# Altium schematic sheet F4 DFC.SchDoc("F4 DFC")
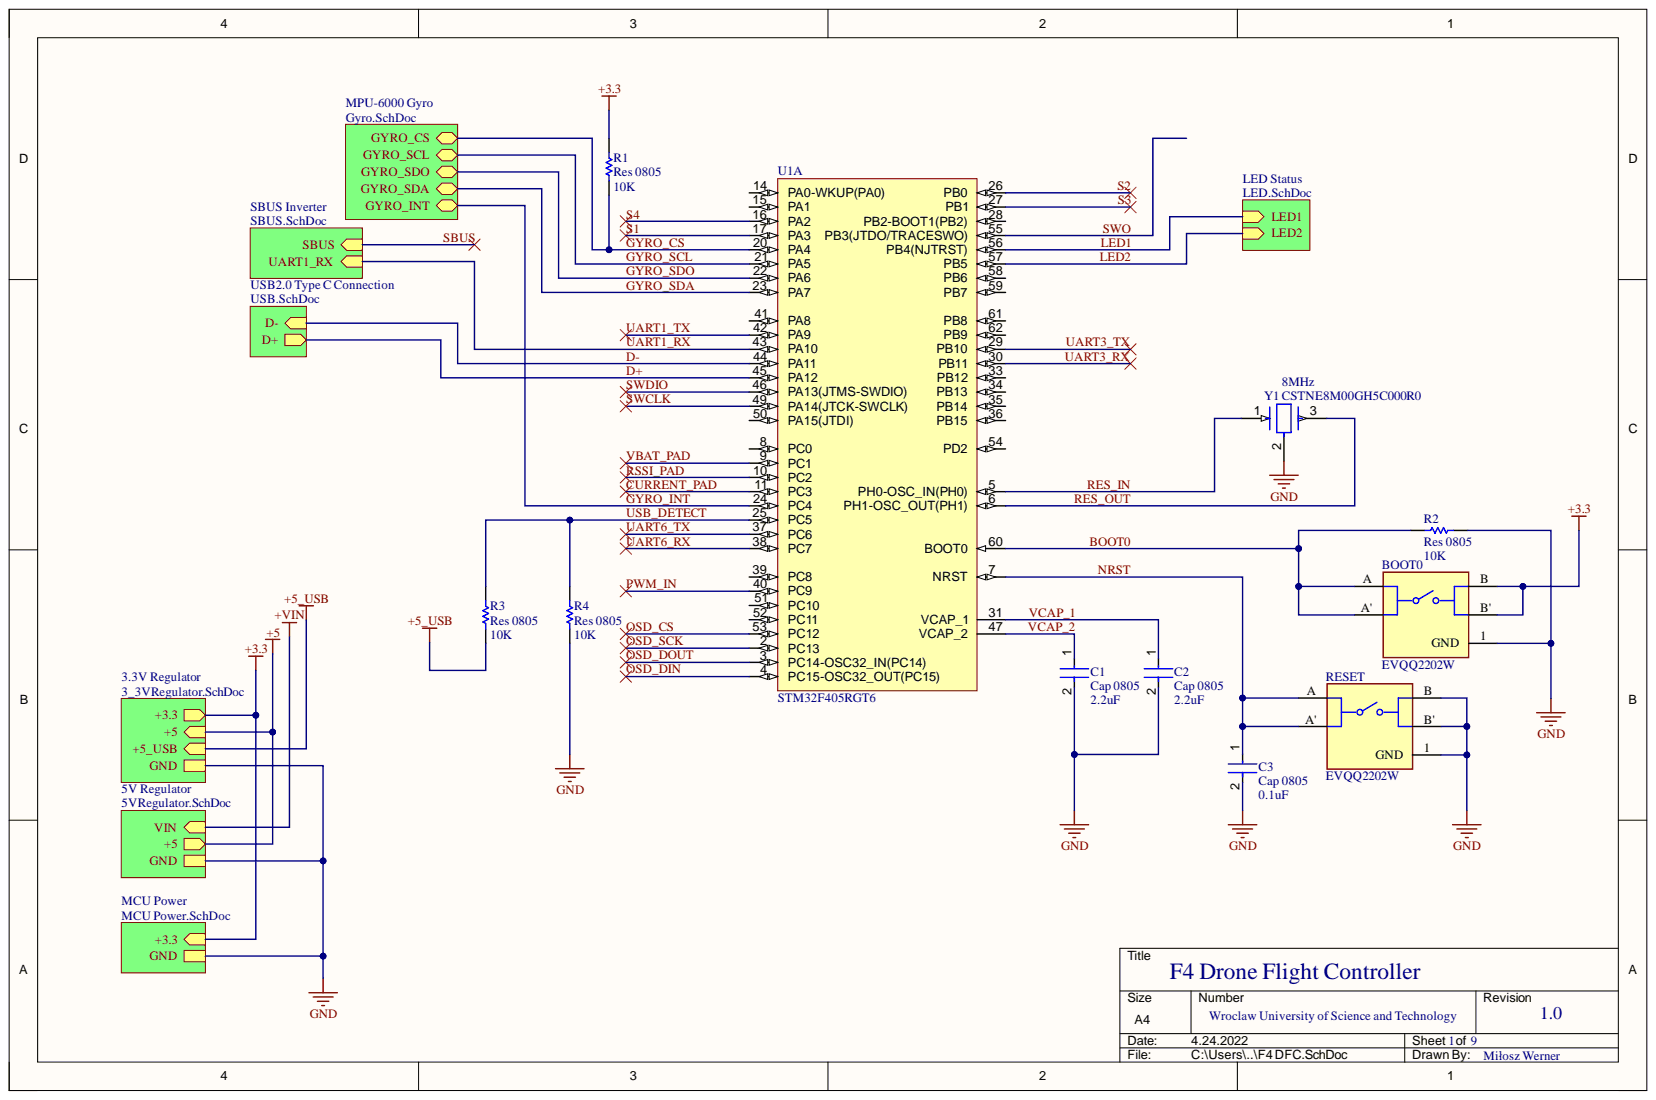
[diagram p0: F4 DFC.SchDoc]

## Parts
BOOT0  EVQQ2202W  [FP-EVQQ2202W-MFG]
C1  Cap 0805  value 2.2uF  [FP-GRM216-0_1-IPC_C]
C2  Cap 0805  value 2.2uF  Case Code (Imperial): 0805  [FP-GRM216-0_1-IPC_C]
C3  Cap 0805  value 0.1uF  [FP-GRM216-0_1-IPC_C]
R1  Res 0805  value 10K  [RESC2013X50X30LL20T20]
R2  Res 0805  value 10K  [RESC2013X50X30LL20T20]
R3  Res 0805  value 10K  [RESC2013X50X30LL20T20]
R4  Res 0805  value 10K  [RESC2013X50X30LL20T20]
RESET  EVQQ2202W  [FP-EVQQ2202W-MFG]
U1  STM32F405RGT6  [STM-LQFP64_V]  (part A)
Y1  CSTNE8M00GH5C000R0  value 8MHz  Frequency: 8MHz  [FP-CSTNE8M00GH5C000R0-MFG]

## Nets
+3.3: BOOT0.B BOOT0.B' R1.2
+5_USB: R3.1
GND: BOOT0.1(GND) C1.2 C2.2 C3.2 R2.1 R4.1 RESET.1(GND) RESET.B RESET.B' Y1.2
BOOT0: BOOT0.A BOOT0.A' R2.2 U1.60(BOOT0)
CURRENT_PAD: U1.11(PC3)
D+: U1.45(PA12)
D-: U1.44(PA11)
GYRO_CS: R1.1 U1.20(PA4)
GYRO_INT: U1.24(PC4)
GYRO_SCL: U1.21(PA5)
GYRO_SDA: U1.23(PA7)
GYRO_SDO: U1.22(PA6)
LED1: U1.56(PB4(NJTRST))
LED2: U1.57(PB5)
NRST: C3.1 RESET.A RESET.A' U1.7(NRST)
OSD_CS: U1.53(PC12)
OSD_DIN: U1.4(PC15-OSC32_OUT(PC15))
OSD_DOUT: U1.3(PC14-OSC32_IN(PC14))
OSD_SCK: U1.2(PC13)
PWM_IN: U1.40(PC9)
RES_IN: U1.5(PH0-OSC_IN(PH0)) Y1.1
RES_OUT: U1.6(PH1-OSC_OUT(PH1)) Y1.3
RSSI_PAD: U1.10(PC2)
S1: U1.17(PA3)
S2: U1.26(PB0)
S3: U1.27(PB1)
S4: U1.16(PA2)
SWCLK: U1.49(PA14(JTCK-SWCLK))
SWDIO: U1.46(PA13(JTMS-SWDIO))
SWO: U1.55(PB3(JTDO/TRACESWO))
UART1_RX: U1.43(PA10)
UART1_TX: U1.42(PA9)
UART3_RX: U1.30(PB11)
UART3_TX: U1.29(PB10)
UART6_RX: U1.38(PC7)
UART6_TX: U1.37(PC6)
USB_DETECT: R3.2 R4.2 U1.25(PC5)
VBAT_PAD: U1.9(PC1)
VCAP_1: C2.1 U1.31(VCAP_1)
VCAP_2: C1.1 U1.47(VCAP_2)
NetU1_8: U1.8(PC0)
NetU1_14: U1.14(PA0-WKUP(PA0))
NetU1_15: U1.15(PA1)
NetU1_28: U1.28(PB2-BOOT1(PB2))
NetU1_33: U1.33(PB12)
NetU1_34: U1.34(PB13)
NetU1_35: U1.35(PB14)
NetU1_36: U1.36(PB15)
NetU1_39: U1.39(PC8)
NetU1_41: U1.41(PA8)
NetU1_50: U1.50(PA15(JTDI))
NetU1_51: U1.51(PC10)
NetU1_52: U1.52(PC11)
NetU1_54: U1.54(PD2)
NetU1_58: U1.58(PB6)
NetU1_59: U1.59(PB7)
NetU1_61: U1.61(PB8)
NetU1_62: U1.62(PB9)
+5:
+VIN:
SBUS:

## Library data of the parts
BOOT0 RESET = CMP-05618-000131-1: SWITCH TACTILE SPST-NO 0.02A 15V; Actuator Color: White; Actuator Length: 0.7mm; Actuator Type: Standard; Body Depth: 6mm; Contact Current Rating: 20mA; Contact Resistance: 100mR; Electrical Life: 100000Cycles; Illumination Type: Non-Illuminated; Max Voltage Rating (AC): 250V; Operating Force: 3.5N; Switch Function: Off-Mom; Switch Travel: 0.25mm; Throw Configuration: SPST; Case/Package: SMD/SMT; Color: White; Component Kind: Standard; Contact Plating: Silver; Current Rating: 20mA; Depth: 6.6mm; Lead Free: Lead Free; Length: 6mm; Library Name: Altium Content Vault; Max Current Rating: 20mA; Max Operating Temperature: 85°C; Max Voltage Rating (DC): 15V; Mechanical Life: 100000Cycles; Min Operating Temperature: -40°C; Mount: Surface Mount; Orientation: Straight; Package Quantity: 1; Packaging: Tape and Reel; Radiation Hardening: No; REACH SVHC: No SVHC; RoHS Compliant: Yes; Series: EVQQ2; Size: 1.8mm; Termination: SMD/SMT; Thickness: 1.8mm; Voltage Rating (AC): 250V; Voltage Rating (DC): 15V
C1-C3 = CMP-2007-00889-2: Capacitor; Capacitance: 100nF; Case/Package: 0805; Component Kind: Standard; Library Name: Altium Content Vault; Mount: Surface Mount
  C1 C3: Case Code (Imperial): 0805
R1 R3 R4 = CMP-2001-03735-1: Resistor; Component Kind: Standard; Library Name: Altium Content Vault
R2 = CMP-2001-03735-1: Resistor; Value: 10K; Component Kind: Standard; Library Name: Altium Content Vault
U1 = CMP-0243-00019-3: ARM Cortex-M4 32-bit MCU+FPU, 210 DMIPS, 1024 kB Flash, 192 kB Internal RAM, 51 I/Os, 64-pin LQFP, -40 to 85 degC, Tray; Manufacturer: STMicroelectronics; D/A Converter: 2x12-bit; FLASH Size (Prog) (kB): 1024; I/Os (High Current): 51; Internal RAM Size (kB): 192; Operating Frequency(F) (Processor speed) (MHz): 168; Supply Voltage(Vcc) max (V): 3.6; Supply Voltage(Vcc) min (V): 1.8; Component Kind: Standard; ComponentLink1Description: Manufacturer URL; ComponentLink1URL: http://www.st.com/web/en/home.html; ComponentLink2Description: Datasheet; ComponentLink2URL: http://www.st.com/st-web-ui/static/active/en/resource/technical/document/datasheet/DM00037051.pdf; ComponentLink3Description: Package Specification; ComponentLink3URL: http://www.st.com/st-web-ui/static/active/en/resource/technical/document/datasheet/DM00037051.pdf; Core: ARM Cortex-M4; DatasheetVersion: Rev. 4; Library Name: Altium Content Vault; Mounting Technology: Surface Mount; PackageDescription: 64-Pin Low Profile Quad Flat Package, 10 x 10 mm Body, 0.5 mm Pitch; PackageReference: LQFP64; PackageVersion: Rev. 4, 06/2013; Packing: Tray; PartNumber: STM32F405RGT6
Y1 = CMP-06057-000001-1: CERAMIC RES 8.0000MHZ 33PF SMD; Frequency Stability: 0.13%; Frequency Tolerance: 0.07%; Impedance: 40R; Capacitance: 33pF; Case/Package: SMD/SMT; Component Kind: Standard; Library Name: Altium Content Vault; Max Operating Temperature: 125°C; Min Operating Temperature: -40°C; Mount: Surface Mount; Packaging: Tape and Reel; Resistor Type: Ceramic
